# Altium schematic sheet Potentiometer Sensors.SchDoc("Potentiometer Sensors")
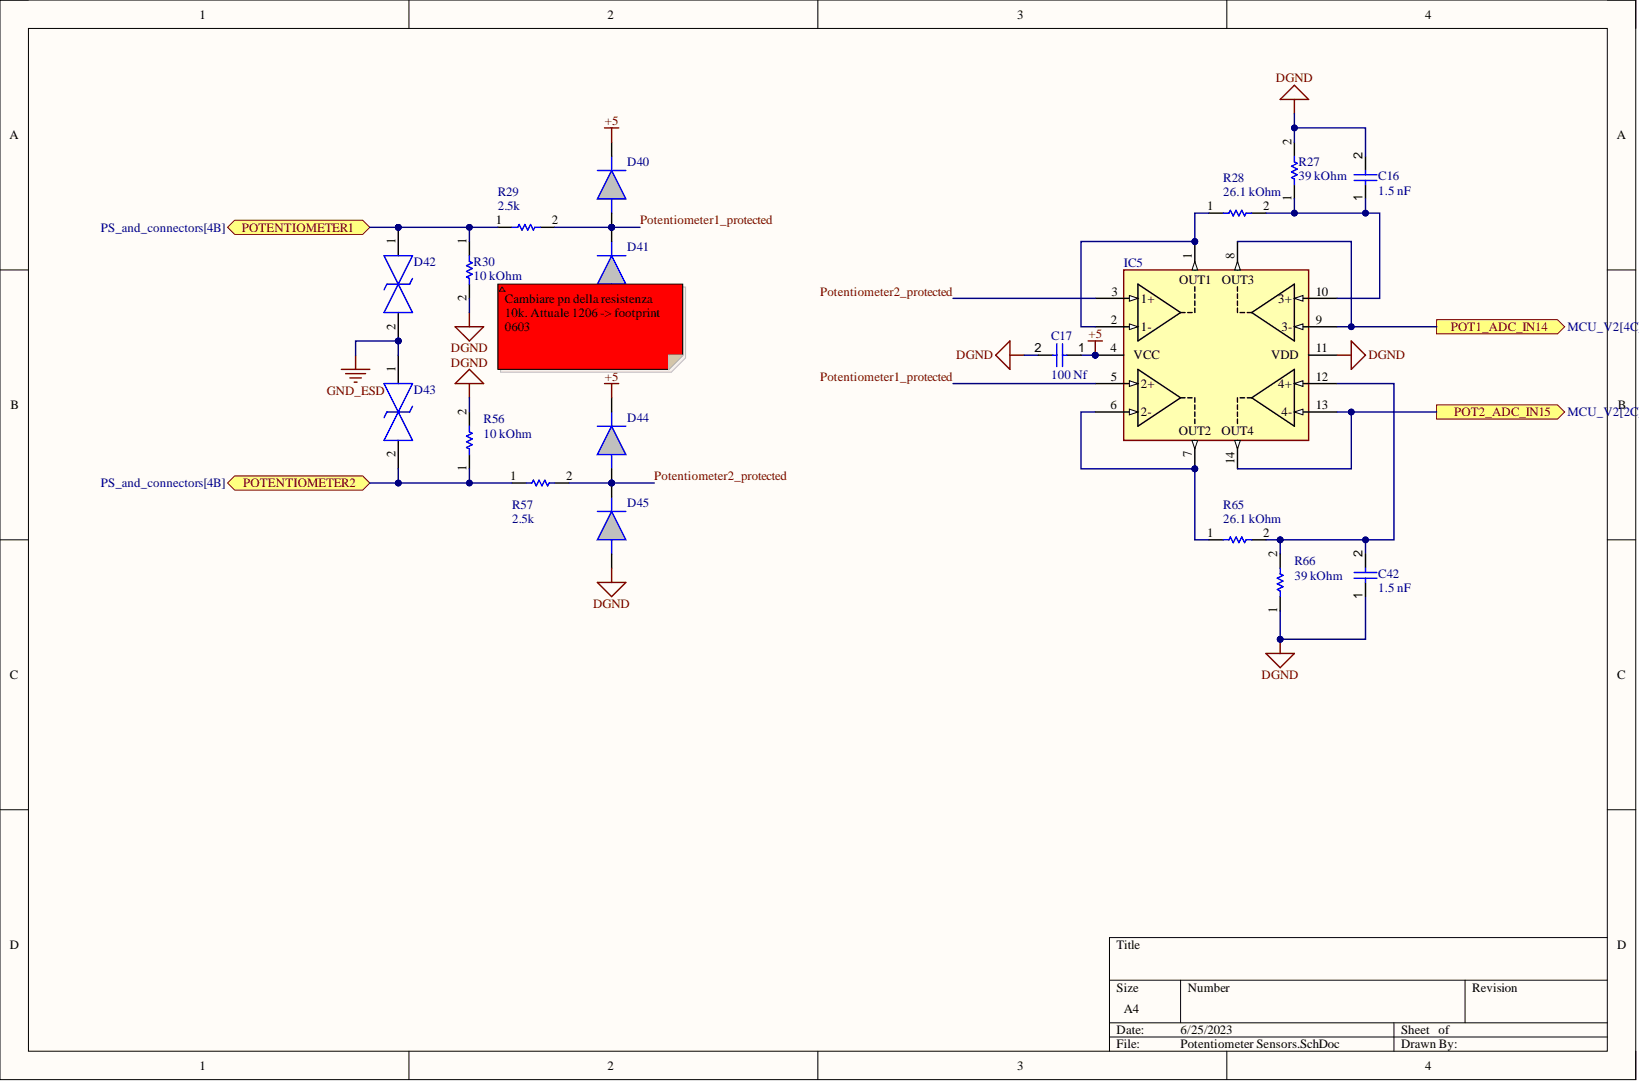
[diagram p0: Potentiometer Sensors.SchDoc]

## Parts
C16  1.5 nF
C17  100 Nf
C42  1.5 nF
D40  CD0603-B0340R  (value not drawn)
D41  CD0603-B0340R  (value not drawn)
D42  SMAJ30CA-TR  (value not drawn)
D43  SMAJ30CA-TR  (value not drawn)
D44  CD0603-B0340R  (value not drawn)
D45  CD0603-B0340R  (value not drawn)
IC5  TSV324IDT  (value not drawn)
R27  39 kOhm
R28  26.1 kOhm
R29  2.5k
R30  10 kOhm
R56  10 kOhm
R57  2.5k
R65  26.1 kOhm
R66  39 kOhm

## Ports
POT1_ADC_IN14, POT2_ADC_IN15, POTENTIOMETER1, POTENTIOMETER2

## Nets
+5: C17.1 D40.1 D44.1 IC5.4(VCC)
DGND: C16.2 C17.2 C42.1 D41.2 D45.2 IC5.11(VDD) R27.2 R30.2 R56.2 R66.1
GND_ESD: D42.2 D43.1
POT1_ADC_IN14: IC5.8 IC5.9(3-) ; port POT1_ADC_IN14
POT2_ADC_IN15: IC5.13(4-) IC5.14 ; port POT2_ADC_IN15
POTENTIOMETER1: D42.1 R29.1 R30.1 ; port POTENTIOMETER1
Potentiometer1_protected: D40.2 D41.1 IC5.5(2+) R29.2
POTENTIOMETER2: D43.2 R56.1 R57.1 ; port POTENTIOMETER2
Potentiometer2_protected: D44.2 D45.1 IC5.3(1+) R57.2
NetC16_1: C16.1 IC5.10(3+) R27.1 R28.2
NetC42_2: C42.2 IC5.12(4+) R65.2 R66.2
NetIC5_1: IC5.1 IC5.2(1-) R28.1
NetIC5_6: IC5.6(2-) IC5.7 R65.1

## Library data of the parts
C16 C42 = CMP-00009-1: 885012207061; Component Kind: Standard; Library Name: EMEA- Squadra Corse PoliTO-  Politecnico di Torino
C17 = CMP-00009-1: VJ0805Y104MXATW1BC; Component Kind: Standard; Library Name: EMEA- Squadra Corse PoliTO-  Politecnico di Torino
D40 D41 D44 D45 = CMP-110-000000-5: Bourns 40V 300mA, Diode, 2-Pin 0603 CD0603-B0340R; Geometry.Height: 0.8mm; Component Kind: Standard; Datasheet Link: https://www.bourns.com/docs/product-datasheets/cd0603-b.pdf; Design Item ID: CD0603-B0340R; Manufacturer_Name: Bourns; Manufacturer_Part_Number: CD0603-B0340R; Mouser Part Number: 652-CD0603-B0340R; Mouser Price/Stock: https://www.mouser.co.uk/ProductDetail/Bourns/CD0603-B0340R?qs=xhbEVWpZdWee9K23%252B3DSjQ%3D%3D; Source: CD0603-B0340R.SchLib; Library Name: EMEA- Squadra Corse PoliTO-  Politecnico di Torino
D42 D43 = CMP-004-000005-1: TVS Diode Bi-Directional SMAJ30CA-TR 64.3V; Manufacturer_Name: STMicroelectronics; Arrow Part Number: SMAJ30CA-TR; Arrow Price/Stock: https://www.arrow.com/en/products/smaj30ca-tr/stmicroelectronics?region=nac; Component Kind: Standard; Datasheet Link: https://www.st.com/resource/en/datasheet/smaj10ca.pdf; Design Item ID: SMAJ30CA-TR; Height: 2.65mm; Manufacturer_Part_Number: SMAJ30CA-TR; Mouser Part Number: 511-SMAJ30CA-TR; Mouser Price/Stock: https://www.mouser.co.uk/ProductDetail/STMicroelectronics/SMAJ30CA-TR?qs=0TJjK1waviDY0EkLaKH12g%3D%3D; Source: SamacSys.SchLib; Library Name: EMEA- Squadra Corse PoliTO-  Politecnico di Torino
IC5 = CMP-007-000004-2: Manufacturer_Name: STMicroelectronics; Arrow Part Number: TSV324IDT; Arrow Price/Stock: https://www.arrow.com/en/products/tsv324idt/stmicroelectronics; Component Kind: Standard; Datasheet Link: http://www.st.com/st-web-ui/static/active/en/resource/technical/document/datasheet/CD00063292.pdf; Description: TSV324IDT; Design Item ID: TSV324IDT; Height: 1.75mm; Manufacturer_Part_Number: TSV324IDT; Mouser Part Number: 511-TSV324IDT; Mouser Price/Stock: https://www.mouser.com/Search/Refine.aspx?Keyword=511-TSV324IDT; Source: SamacSys.SchLib; Library Name: EMEA- Squadra Corse PoliTO-  Politecnico di Torino
R27 R66 = CMP-009-00179-4: RT0603BRD0739KL; Tech: SMD; Component Kind: Standard; ComponentLink1Description: Website; Package: 0603; Library Name: EMEA- Squadra Corse PoliTO-  Politecnico di Torino
R28 R65 = CMP-009-00179-4: RT0603BRD0726K1L; Tech: SMD; Component Kind: Standard; ComponentLink1Description: Website; Package: 0603; Library Name: EMEA- Squadra Corse PoliTO-  Politecnico di Torino
R29 R30 R56 = CMP-009-00179-4: RC1206FR-0710KL; Tech: SMD; Component Kind: Standard; ComponentLink1Description: Website; Package: 0603; Library Name: EMEA- Squadra Corse PoliTO-  Politecnico di Torino
R57 = CMP-009-00179-5: RC1206FR-0710KL; Tech: SMD; Component Kind: Standard; ComponentLink1Description: Website; Package: 0603; Library Name: EMEA- Squadra Corse PoliTO-  Politecnico di Torino
